# Altium schematic sheet ESP8266.SchDoc(ESP8266)
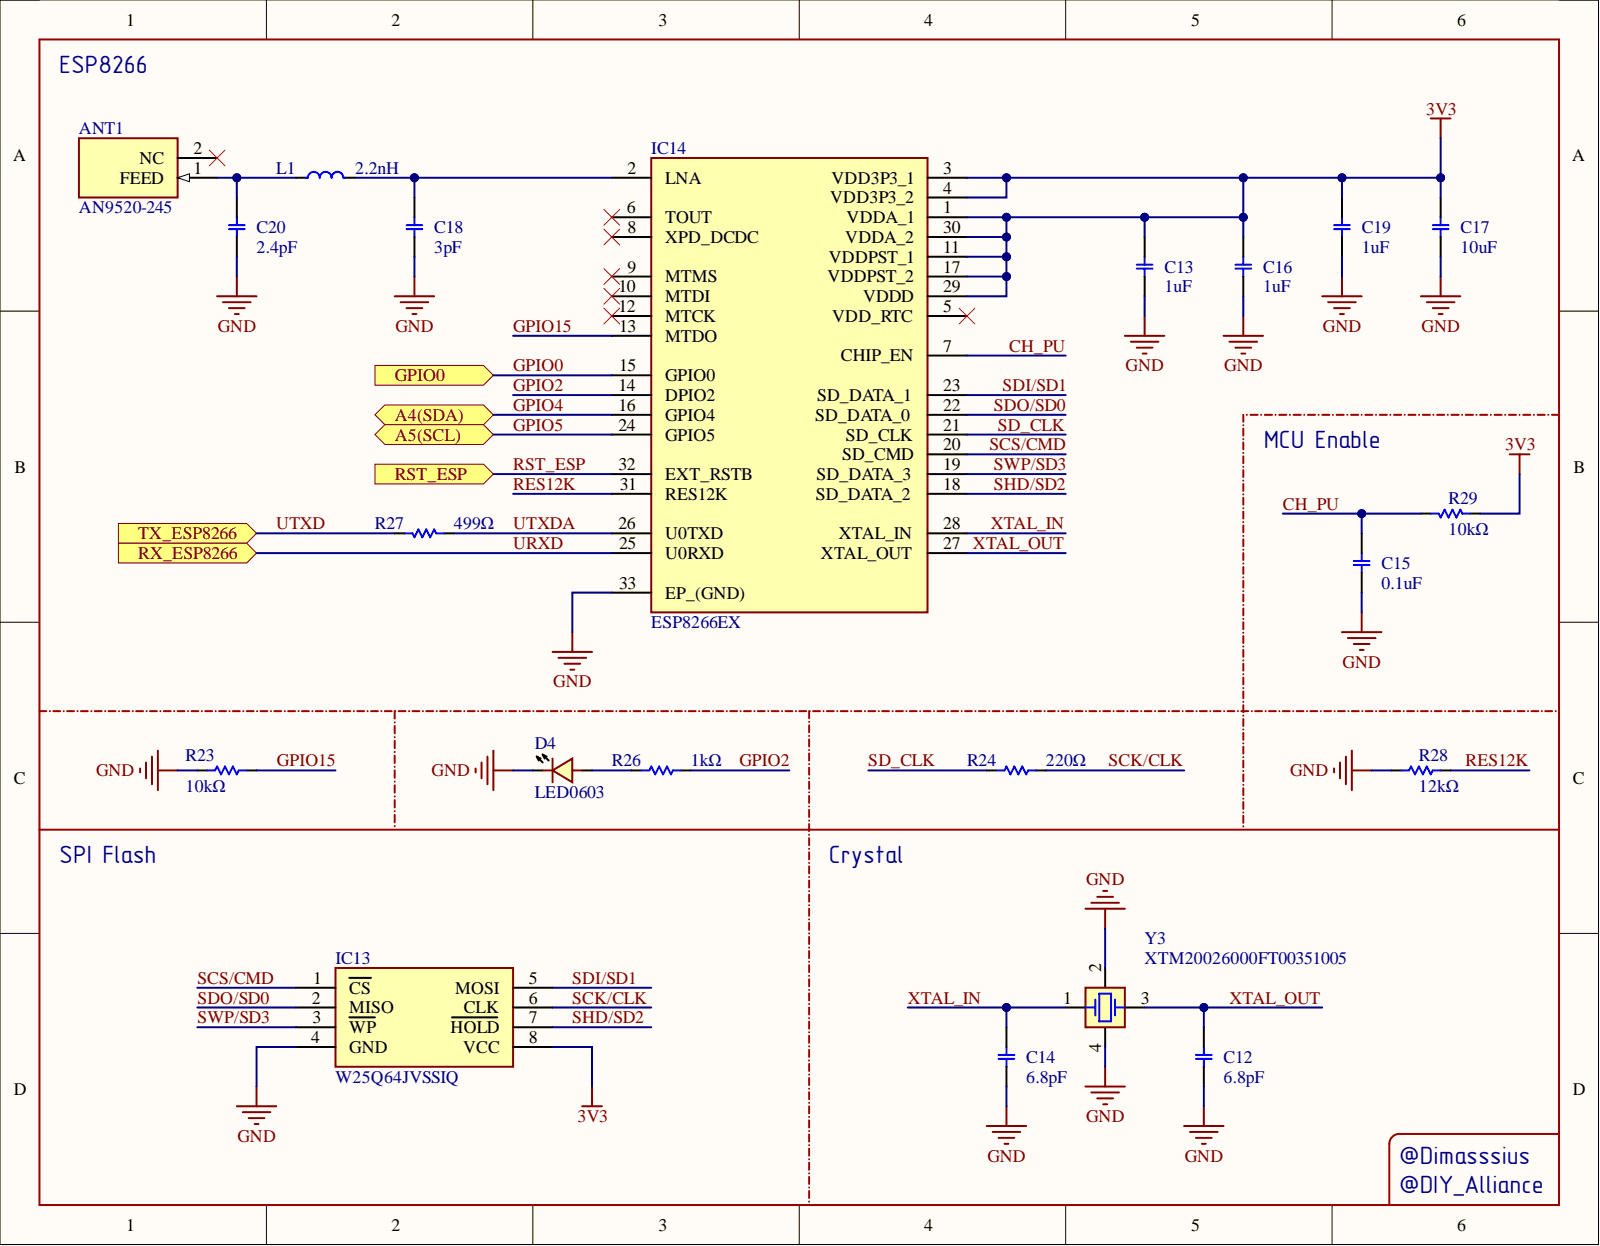
[diagram p0: ESP8266.SchDoc]

## Parts
ANT1  AN9520-245  [ANTC9520]
C12  6.8pF  [CAP_SMD_MLCC_C0603_(M1608)_C0402A_(M1005A)]
C13  1uF  [CAP_SMD_MLCC_C0603_(M1608)_C0402A_(M1005A)]
C14  6.8pF  [CAP_SMD_MLCC_C0603_(M1608)_C0402A_(M1005A)]
C15  0.1uF  [CAP_SMD_MLCC_C0603_(M1608)_C0402A_(M1005A)]
C16  1uF  [CAP_SMD_MLCC_C0603_(M1608)_C0402A_(M1005A)]
C17  10uF  [CAP_SMD_MLCC_C0603_(M1608)_C0402A_(M1005A)]
C18  3pF  [CAP_SMD_MLCC_C0603_(M1608)_C0402A_(M1005A)]
C19  1uF  [CAP_SMD_MLCC_C0603_(M1608)_C0402A_(M1005A)]
C20  2.4pF  [CAP_SMD_MLCC_C0603_(M1608)_C0402A_(M1005A)]
D4  LED0603  [LED 0603_(1608)_0402A_(1005)]
IC13  W25Q64JVSSIQ  [SOIC127P798X216-8N]
IC14  ESP8266EX  [QFN50P500X500X90-33N-D]
L1  2.2nH  [IND_SMD_0603_(M1608)]
R23  10kΩ  [RES_SMD_R0603_(M1608)_R0402A_(M1005A)]
R24  220Ω  [RES_SMD_R0603_(M1608)_R0402A_(M1005A)]
R26  1kΩ  [RES_SMD_R0603_(M1608)_R0402A_(M1005A)]
R27  499Ω  [RES_SMD_R0603_(M1608)_R0402A_(M1005A)]
R28  12kΩ  [RES_SMD_R0603_(M1608)_R0402A_(M1005A)]
R29  10kΩ  [RES_SMD_R0603_(M1608)_R0402A_(M1005A)]
Y3  XTM20026000FT00351005  [XTL_4_3225]

## Ports
A4(SDA), A5(SCL), GPIO0, RST_ESP, RX_ESP8266, TX_ESP8266

## Nets
3V3: C13.2 C16.1 C17.2 C19.2 IC13.8(VCC) IC14.1(VDDA_1) IC14.3(VDD3P3_1) IC14.4(VDD3P3_2) IC14.11(VDDPST_1) IC14.17(VDDPST_2) IC14.29(VDDD) IC14.30(VDDA_2) R29.1
GND: C12.1 C13.1 C14.1 C15.1 C16.2 C17.1 C18.2 C19.1 C20.2 D4.1 IC13.4(GND) IC14.33(EP_(GND)) R23.1 R28.2 Y3.2 Y3.4
CH_PU: C15.2 IC14.7(CHIP_EN) R29.2
GPIO0: IC14.15(GPIO0) ; port GPIO0
GPIO2: IC14.14(DPIO2) R26.2
GPIO4: IC14.16(GPIO4) ; port A4(SDA)
GPIO5: IC14.24(GPIO5) ; port A5(SCL)
GPIO15: IC14.13(MTDO) R23.2
RES12K: IC14.31(RES12K) R28.1
RST_ESP: IC14.32(EXT_RSTB) ; port RST_ESP
SCK/CLK: IC13.6(CLK) R24.2
SCS/CMD: IC13.1(CS) IC14.20(SD_CMD)
SD_CLK: IC14.21(SD_CLK) R24.1
SDI/SD1: IC13.5(MOSI) IC14.23(SD_DATA_1)
SDO/SD0: IC13.2(MISO) IC14.22(SD_DATA_0)
SHD/SD2: IC13.7(HOLD) IC14.18(SD_DATA_2)
SWP/SD3: IC13.3(WP) IC14.19(SD_DATA_3)
URXD: IC14.25(U0RXD) ; port RX_ESP8266
UTXD: R27.2 ; port TX_ESP8266
UTXDA: IC14.26(U0TXD) R27.1
XTAL_IN: C14.2 IC14.28(XTAL_IN) Y3.1
XTAL_OUT: C12.2 IC14.27(XTAL_OUT) Y3.3
NetANT1_1: ANT1.1 C20.1 L1.1
NetC18_1: C18.1 IC14.2(LNA) L1.2
NetD4_2: D4.2 R26.1

Not connected: ANT1 2; IC14 5(VDD_RTC) 6(TOUT) 8(XPD_DCDC) 9(MTMS) 10(MTDI) 12(MTCK)

## Library data of the parts
ANT1 = AN9520-245: Antenna, SMD, 2.1x9.5mm, 1.5dBi, Patch Antenna, 200MHz, 2.45GHz, 3W, Antennas ROHS; Manufacturer_Name: RAINBOW SW INDUSTRY  CO.,LIMITED; Manufacturer_Part_Number: AN2051-245; Component Kind: Standard; Datasheet Link: https://www.micros.com.pl/mediaserver/info-rf%20an2051-245.pdf; Height: 1.27mm; Library Name: SamacSys.SchLib; Value: AN9520-245
C12 C14 = CAP_SMD_MLCC_6.8PF_50V: Capacitor, Ceramic (MLCC), SMD, 6.8pF, 50V, ±5%, C0G, Multilayer Ceramic Capacitors MLCC - SMD/SMT, ROHS; Manufacturer: Murata Electronics North America; Part Number: GRM319R71C224KA01D; @ qty: 0; Minimum Quantity: 1; Temperature Coefficient: X7R; Tolerance: ±10%; Unit Price (USD): 0.26; Voltage: 16V; Applications: General Purpose; Component Kind: Standard; Datasheets: http://www.murata.com/~/media/webrenewal/support/library/catalog/products/capacitor/mlcc/c02e.pdf; Digi-Key Part Number: 490-11608-1-ND; Factory Stock: 0; Features: -; Height - Seated (Max): -; Image: //media.digikey.com/Renders/Murata%20Renders/GR331BD72E473KW01L.jpg; Lead Spacing: -; Lead Style: -; Library Name: Capacitors.SchLib; Mounting Type: Surface Mount, MLCC; Operating Temperature: -55°C ~ 125°C; Package / Case: -; Packaging: Cut Tape (CT); Part Status: Active; Quantity Available: 10161; Ratings: -; Series: GRM; Size / Dimension: -; Thickness (Max): -; Value: 6.8pF
C13 C16 C19 = CAP_SMD_MLCC_1UF_6.3V: Capacitor, Ceramic (MLCC), SMD, 1uF, 6.3V, ±10%, X5R, Multilayer Ceramic Capacitors MLCC - SMD/SMT, ROHS; Manufacturer: Murata Electronics North America; Part Number: GRM319R61A105KA01D; @ qty: 0; Minimum Quantity: 1; Unit Price (USD): 0.28; Voltage: 10V; Applications: General Purpose; Component Kind: Standard; Datasheets: http://www.murata.com/~/media/webrenewal/support/library/catalog/products/capacitor/mlcc/c02e.pdf; Digi-Key Part Number: 490-11607-1-ND; Factory Stock: 0; Features: -; Height - Seated (Max): -; Image: //media.digikey.com/Renders/Murata%20Renders/GR331BD72E473KW01L.jpg; Lead Spacing: -; Lead Style: -; Library Name: Capacitors.SchLib; Mounting Type: Surface Mount, MLCC; Operating Temperature: -55°C ~ 85°C; Package / Case: -; Packaging: Cut Tape (CT); Part Status: Active; Quantity Available: 3593; Ratings: -; Series: GRM; Size / Dimension: -; Temperature Coefficient: X5R; Thickness (Max): -; Tolerance: ±10%; Value: 1uF
C15 = CAP_SMD_MLCC_0.1UF_6.3V: Capacitor, Ceramic (MLCC), SMD, 100nF, 6.3V, ±10%, X7R, Multilayer Ceramic Capacitors MLCC - SMD/SMT, ROHS; Manufacturer: Murata Electronics North America; Part Number: LLM315R71C104MA11L; @ qty: 0; Minimum Quantity: 1; Tolerance: ±20%; Unit Price (USD): 0.9; Voltage: 16V; Applications: Bypass, Decoupling; Component Kind: Standard; Datasheets: http://www.murata.com/~/media/webrenewal/support/library/catalog/products/capacitor/mlcc/c02e.pdf; Digi-Key Part Number: 490-4495-1-ND; Factory Stock: 0; Features: Low ESL (Multi-Terminal); Height - Seated (Max): -; Image: //media.digikey.com/Renders/Murata%20Renders/1206(LLM315).jpg; Lead Spacing: -; Lead Style: -; Library Name: Capacitors.SchLib; Mounting Type: Surface Mount, MLCC; Operating Temperature: -55°C ~ 125°C; Package / Case: -; Packaging: Cut Tape (CT); Part Status: Active; Quantity Available: 2915; Ratings: -; Series: LLM; Size / Dimension: -; Temperature Coefficient: X7R; Thickness (Max): -; Value: 0.1uF
C17 = CAP_SMD_MLCC_10UF_6.3V: Capacitor, Ceramic (MLCC), SMD, 10uF, 6.3V, ±20%, X5R, Multilayer Ceramic Capacitors MLCC - SMD/SMT, ROHS; Manufacturer: Murata Electronics North America; Part Number: GRM31CR60J106KA01L; @ qty: 0; Minimum Quantity: 1; Tolerance: ±10%; Unit Price (USD): 0.24; Applications: General Purpose; Component Kind: Standard; Datasheets: http://www.murata.com/~/media/webrenewal/support/library/catalog/products/capacitor/mlcc/c02e.pdf; Digi-Key Part Number: 490-12610-1-ND; Factory Stock: 0; Features: -; Height - Seated (Max): -; Image: //media.digikey.com/Renders/Murata%20Renders/GR331BD72E473KW01L.jpg; Lead Spacing: -; Lead Style: -; Library Name: Capacitors.SchLib; Mounting Type: Surface Mount, MLCC; Operating Temperature: -55°C ~ 85°C; Package / Case: -; Packaging: Cut Tape (CT); Part Status: Active; Quantity Available: 12001; Ratings: -; Series: GRM; Size / Dimension: -; Temperature Coefficient: X5R; Thickness (Max): -; Value: 10uF; Voltage: 6.3V
C18 = CAP_SMD_MLCC_3PF_50V: Capacitor, Ceramic (MLCC), SMD, 3pF, 50V, ±5%, C0G, Multilayer Ceramic Capacitors MLCC - SMD/SMT, ROHS; Manufacturer: Murata Electronics North America; Part Number: GRM319R71C224KA01D; @ qty: 0; Minimum Quantity: 1; Temperature Coefficient: X7R; Tolerance: ±10%; Unit Price (USD): 0.26; Voltage: 16V; Applications: General Purpose; Component Kind: Standard; Datasheets: http://www.murata.com/~/media/webrenewal/support/library/catalog/products/capacitor/mlcc/c02e.pdf; Digi-Key Part Number: 490-11608-1-ND; Factory Stock: 0; Features: -; Height - Seated (Max): -; Image: //media.digikey.com/Renders/Murata%20Renders/GR331BD72E473KW01L.jpg; Lead Spacing: -; Lead Style: -; Library Name: Capacitors.SchLib; Mounting Type: Surface Mount, MLCC; Operating Temperature: -55°C ~ 125°C; Package / Case: -; Packaging: Cut Tape (CT); Part Status: Active; Quantity Available: 10161; Ratings: -; Series: GRM; Size / Dimension: -; Thickness (Max): -; Value: 3pF
C20 = CAP_SMD_MLCC_2.4PF_50V: Capacitor, Ceramic (MLCC), SMD, 2.4pF, 50V, ±5%, C0G, Multilayer Ceramic Capacitors MLCC - SMD/SMT, ROHS; Manufacturer: Murata Electronics North America; Part Number: GRM319R71C224KA01D; @ qty: 0; Minimum Quantity: 1; Temperature Coefficient: X7R; Tolerance: ±10%; Unit Price (USD): 0.26; Voltage: 16V; Applications: General Purpose; Component Kind: Standard; Datasheets: http://www.murata.com/~/media/webrenewal/support/library/catalog/products/capacitor/mlcc/c02e.pdf; Digi-Key Part Number: 490-11608-1-ND; Factory Stock: 0; Features: -; Height - Seated (Max): -; Image: //media.digikey.com/Renders/Murata%20Renders/GR331BD72E473KW01L.jpg; Lead Spacing: -; Lead Style: -; Library Name: Capacitors.SchLib; Mounting Type: Surface Mount, MLCC; Operating Temperature: -55°C ~ 125°C; Package / Case: -; Packaging: Cut Tape (CT); Part Status: Active; Quantity Available: 10161; Ratings: -; Series: GRM; Size / Dimension: -; Thickness (Max): -; Value: 2.4pF
D4 = XL-1608SURC-06: LED Indication - Discrete, 0603, 20mA, 225mcd, Colorless Transparent Lens, -20℃~+85℃, Positive Stick, 620nm~630nm, Red, 120°, 50mW, 2.3V, LED Indication - Discrete, ROHS; Component Kind: Standard; Datasheet Link: https://katalog.we-online.de/led/datasheet/150060GS55040.pdf; Height: 0.5mm; Library Name: SamacSys.SchLib; Value: LED0603
IC13 = W25Q64JVSSIQ: NOR FLASH, SOIC-8-208mil, 64Mbit, SPI, 133MHz, NOR FLASH, ROHS; Component Kind: Standard; Library Name: SamacSys.SchLib; Value: W25Q64JVSSIQ
IC14 = ESP8266EX: Microcontroller, QFN-32-EP(5x5), 72.2Mbps, 2400MHz~2483.5MHz, WiFi 2.4GHz, RF Transceiver ICs, ROHS; Component Kind: Standard; Height: 0.9mm; Library Name: SamacSys.SchLib; Value: ESP8266EX
L1 = SCC1608C2N2SSP: Fixed Inductor, SMD, 0603, 2.2nH, 500mA, ±5%, 200mΩ, Fixed Inductors, RoHS; Component Kind: Standard; Library Name: SamacSys.SchLib; Value: 2.2nH
R23 R29 = RES_SMD_10KΩ: Resistor, Thick Film Resistor, SMD, 10kΩ, ±5%, Chip Resistor - Surface Mount, ROHS; Component Kind: Standard; Library Name: Resistors.SchLib; Value: 10kΩ
R24 = RES_SMD_220Ω: Resistor, Thick Film Resistor, SMD, 220Ω, ±5%, Chip Resistor - Surface Mount, ROHS; Component Kind: Standard; Library Name: Resistors.SchLib; Value: 220Ω
R26 = RES_SMD_1KΩ: Resistor, Thick Film Resistor, SMD, 1kΩ, ±5%, Chip Resistor - Surface Mount, ROHS; Component Kind: Standard; Library Name: Resistors.SchLib; Value: 1kΩ
R27 = RES_SMD_499Ω: Resistor, Thick Film Resistor, SMD, 499Ω, ±5%, Chip Resistor - Surface Mount, ROHS; Component Kind: Standard; Library Name: Resistors.SchLib; Value: 499Ω
R28 = RES_SMD_12KΩ: Resistor, Thick Film Resistor, SMD, 12kΩ, ±5%, Chip Resistor - Surface Mount, ROHS; Component Kind: Standard; Library Name: Resistors.SchLib; Value: 12kΩ
Y3 = TAXM8M4RFDCET2T: Crystal Oscillator, SMD, SMD3225-4P, 3.2x2.5mm, 26MHz, 12pF, ±10ppm, ±20ppm, Crystals, ROHS; Component Kind: Standard; Datasheet Link: https://datasheet.datasheetarchive.com/originals/distributors/Datasheets_SAMA/e448b11b6d77781431a8823f463adabd.pdf; Height: 0.9mm; Library Name: SamacSys.SchLib; Value: XTM20026000FT00351005
